# Altium schematic sheet Eco-Stationnement_Parking_LED.SchDoc(Eco-Stationnement_Parking_LED)
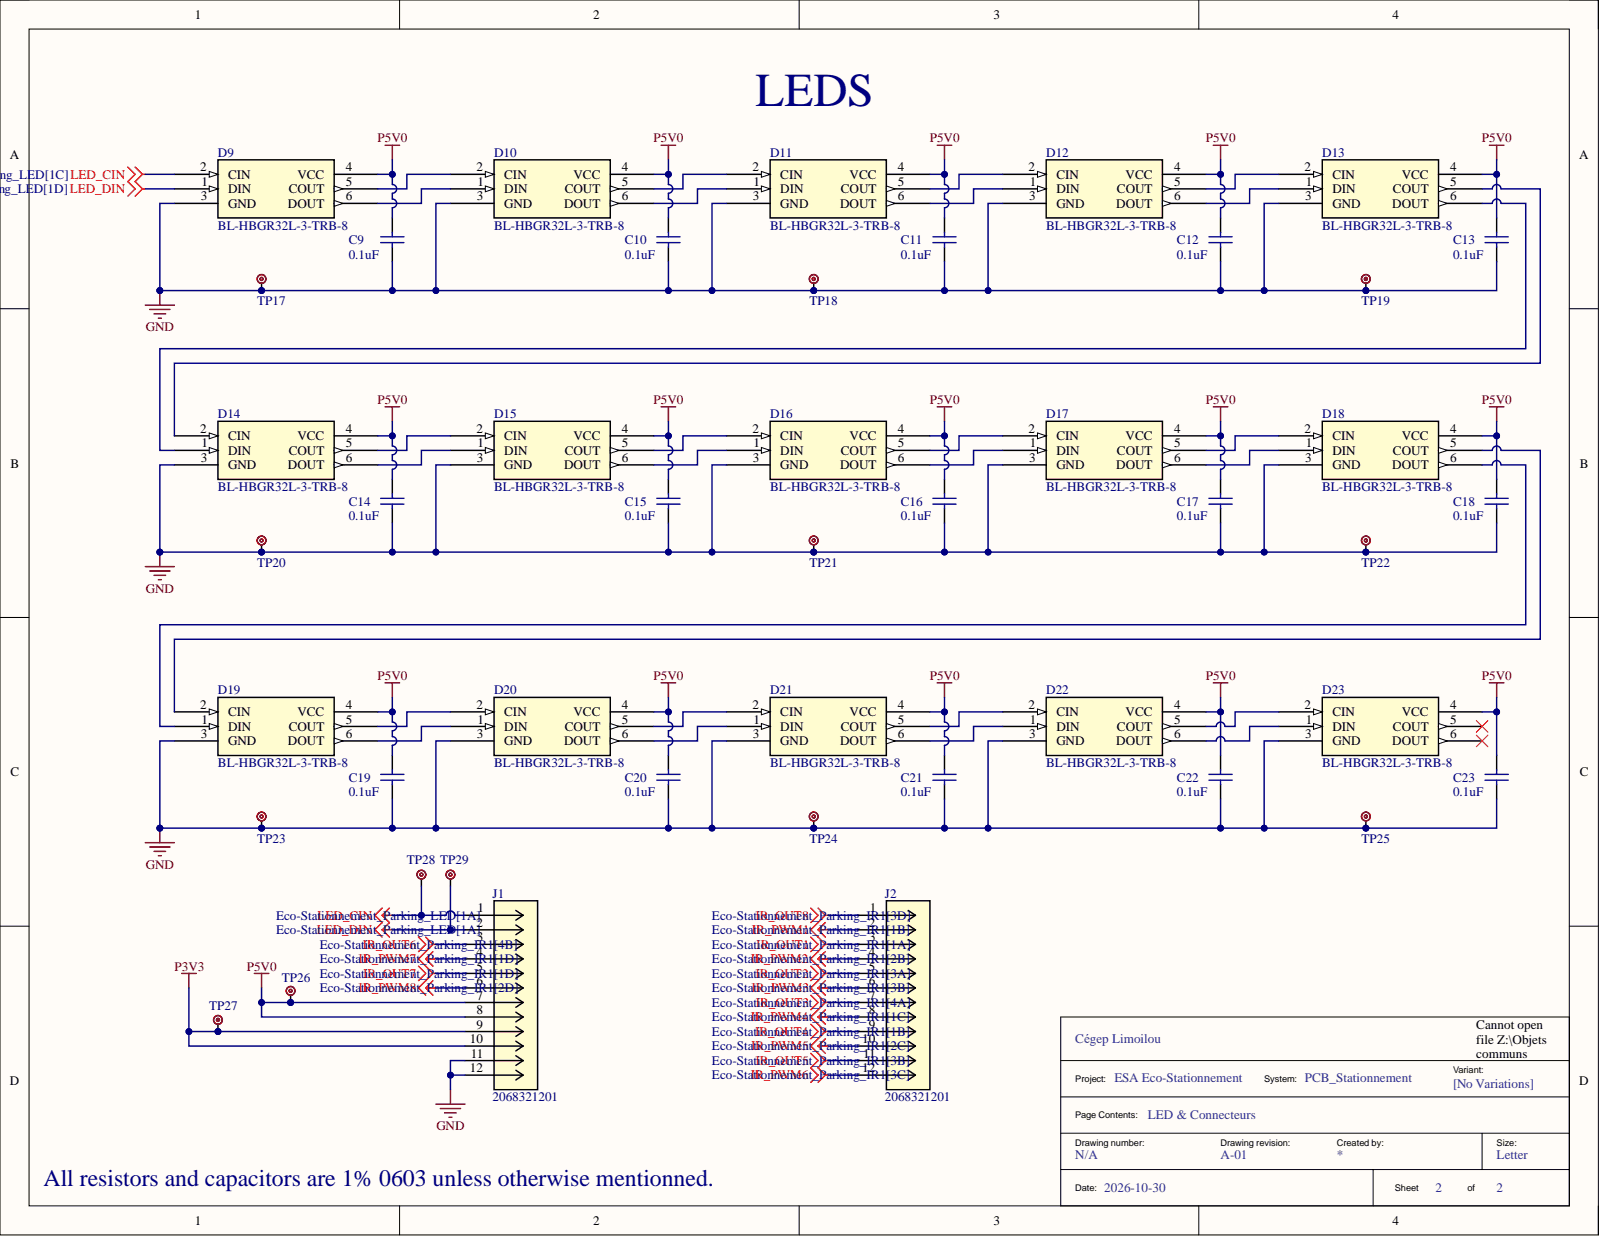
[diagram p0: Eco-Stationnement_Parking_LED.SchDoc]

## Parts
C9  value 0.1uF  [C0603]  (hidden comment: 06035C104KAT2A)
C10  value 0.1uF  [C0603]  (hidden comment: 06035C104KAT2A)
C11  value 0.1uF  [C0603]  (hidden comment: 06035C104KAT2A)
C12  value 0.1uF  [C0603]  (hidden comment: 06035C104KAT2A)
C13  value 0.1uF  [C0603]  (hidden comment: 06035C104KAT2A)
C14  value 0.1uF  [C0603]  (hidden comment: 06035C104KAT2A)
C15  value 0.1uF  [C0603]  (hidden comment: 06035C104KAT2A)
C16  value 0.1uF  [C0603]  (hidden comment: 06035C104KAT2A)
C17  value 0.1uF  [C0603]  (hidden comment: 06035C104KAT2A)
C18  value 0.1uF  [C0603]  (hidden comment: 06035C104KAT2A)
C19  value 0.1uF  [C0603]  (hidden comment: 06035C104KAT2A)
C20  value 0.1uF  [C0603]  (hidden comment: 06035C104KAT2A)
C21  value 0.1uF  [C0603]  (hidden comment: 06035C104KAT2A)
C22  value 0.1uF  [C0603]  (hidden comment: 06035C104KAT2A)
C23  value 0.1uF  [C0603]  (hidden comment: 06035C104KAT2A)
D9  BL-HBGR32L-3-TRB-8  [BL-HBGR32L-3-TRB-8_AMB]
D10  BL-HBGR32L-3-TRB-8  [BL-HBGR32L-3-TRB-8_AMB]
D11  BL-HBGR32L-3-TRB-8  [BL-HBGR32L-3-TRB-8_AMB]
D12  BL-HBGR32L-3-TRB-8  [BL-HBGR32L-3-TRB-8_AMB]
D13  BL-HBGR32L-3-TRB-8  [BL-HBGR32L-3-TRB-8_AMB]
D14  BL-HBGR32L-3-TRB-8  [BL-HBGR32L-3-TRB-8_AMB]
D15  BL-HBGR32L-3-TRB-8  [BL-HBGR32L-3-TRB-8_AMB]
D16  BL-HBGR32L-3-TRB-8  [BL-HBGR32L-3-TRB-8_AMB]
D17  BL-HBGR32L-3-TRB-8  [BL-HBGR32L-3-TRB-8_AMB]
D18  BL-HBGR32L-3-TRB-8  [BL-HBGR32L-3-TRB-8_AMB]
D19  BL-HBGR32L-3-TRB-8  [BL-HBGR32L-3-TRB-8_AMB]
D20  BL-HBGR32L-3-TRB-8  [BL-HBGR32L-3-TRB-8_AMB]
D21  BL-HBGR32L-3-TRB-8  [BL-HBGR32L-3-TRB-8_AMB]
D22  BL-HBGR32L-3-TRB-8  [BL-HBGR32L-3-TRB-8_AMB]
D23  BL-HBGR32L-3-TRB-8  [BL-HBGR32L-3-TRB-8_AMB]
J1  2068321201  [CONN_2068320000-SD_12_MOL]
J2  2068321201  [CONN_2068320000-SD_12_MOL]
TP17  5000  [TP_5000_MIN]  (value not drawn)
TP18  5000  [TP_5000_MIN]  (value not drawn)
TP19  5000  [TP_5000_MIN]  (value not drawn)
TP20  5000  [TP_5000_MIN]  (value not drawn)
TP21  5000  [TP_5000_MIN]  (value not drawn)
TP22  5000  [TP_5000_MIN]  (value not drawn)
TP23  5000  [TP_5000_MIN]  (value not drawn)
TP24  5000  [TP_5000_MIN]  (value not drawn)
TP25  5000  [TP_5000_MIN]  (value not drawn)
TP26  5000  [TP_5000_MIN]  (value not drawn)
TP27  5000  [TP_5000_MIN]  (value not drawn)
TP28  5000  [TP_5000_MIN]  (value not drawn)
TP29  5000  [TP_5000_MIN]  (value not drawn)

## Nets
GND: C9.1 C10.1 C11.1 C12.1 C13.1 C14.1 C15.1 C16.1 C17.1 C18.1 C19.1 C20.1 C21.1 C22.1 C23.1 D9.3(GND) D10.3(GND) D11.3(GND) D12.3(GND) D13.3(GND) D14.3(GND) D15.3(GND) D16.3(GND) D17.3(GND) D18.3(GND) D19.3(GND) D20.3(GND) D21.3(GND) D22.3(GND) D23.3(GND) J1.11 J1.12 TP17.1 TP18.1 TP19.1 TP20.1 TP21.1 TP22.1 TP23.1 TP24.1 TP25.1
IR_OUT1: J2.3
IR_OUT2: J2.5
IR_OUT3: J2.7
IR_OUT4: J2.9
IR_OUT5: J2.11
IR_OUT6: J1.3
IR_OUT7: J1.5
IR_OUT8: J2.1
IR_PWM1: J2.2
IR_PWM2: J2.4
IR_PWM3: J2.6
IR_PWM4: J2.8
IR_PWM5: J2.10
IR_PWM6: J2.12
IR_PWM7: J1.4
IR_PWM8: J1.6
LED_CIN: D9.2(CIN) J1.1 TP28.1
LED_DIN: D9.1(DIN) J1.2 TP29.1
P3V3: J1.9 J1.10 TP27.1
P5V0: C9.2 C10.2 C11.2 C12.2 C13.2 C14.2 C15.2 C16.2 C17.2 C18.2 C19.2 C20.2 C21.2 C22.2 C23.2 D9.4(VCC) D10.4(VCC) D11.4(VCC) D12.4(VCC) D13.4(VCC) D14.4(VCC) D15.4(VCC) D16.4(VCC) D17.4(VCC) D18.4(VCC) D19.4(VCC) D20.4(VCC) D21.4(VCC) D22.4(VCC) D23.4(VCC) J1.7 J1.8 TP26.1
NetD9_5: D9.5(COUT) D10.2(CIN)
NetD9_6: D9.6(DOUT) D10.1(DIN)
NetD10_5: D10.5(COUT) D11.2(CIN)
NetD10_6: D10.6(DOUT) D11.1(DIN)
NetD11_5: D11.5(COUT) D12.2(CIN)
NetD11_6: D11.6(DOUT) D12.1(DIN)
NetD12_5: D12.5(COUT) D13.2(CIN)
NetD12_6: D12.6(DOUT) D13.1(DIN)
NetD13_5: D13.5(COUT) D14.2(CIN)
NetD13_6: D13.6(DOUT) D14.1(DIN)
NetD14_5: D14.5(COUT) D15.2(CIN)
NetD14_6: D14.6(DOUT) D15.1(DIN)
NetD15_5: D15.5(COUT) D16.2(CIN)
NetD15_6: D15.6(DOUT) D16.1(DIN)
NetD16_5: D16.5(COUT) D17.2(CIN)
NetD16_6: D16.6(DOUT) D17.1(DIN)
NetD17_5: D17.5(COUT) D18.2(CIN)
NetD17_6: D17.6(DOUT) D18.1(DIN)
NetD18_5: D18.5(COUT) D19.2(CIN)
NetD18_6: D18.6(DOUT) D19.1(DIN)
NetD19_5: D19.5(COUT) D20.2(CIN)
NetD19_6: D19.6(DOUT) D20.1(DIN)
NetD20_5: D20.5(COUT) D21.2(CIN)
NetD20_6: D20.6(DOUT) D21.1(DIN)
NetD21_5: D21.5(COUT) D22.2(CIN)
NetD21_6: D21.6(DOUT) D22.1(DIN)
NetD22_5: D22.5(COUT) D23.2(CIN)
NetD22_6: D22.6(DOUT) D23.1(DIN)

Not connected: D23 5(COUT) 6(DOUT)

## Library data of the parts
C9-C23 = CAP0021: CAP CER 0.1UF 50V X7R 0603 10%; Manufacturer: KYOCERA AVX; ID_CEP: MCAP0021; Verif_1: RCA le 2022-02-21; Component Kind: Standard; Dielectric: X7R; Fitted: Fitted; Package: 0603; PN_Manuf: 06035C104KAT2A; Library Name: Eco-Stationnement_Parking.SchLib
D9-D23 = BL-HBGR32L-3-TRB-8: ADDRESS LED DISCRETE SERIAL RGB; Manufacturer: American Bright; Component Kind: Standard; Fitted: Fitted; Manufacturer_Name: American Bright; Manufacturer_Part_Number: BL-HBGR32L-3-TRB-8; PN_Manuf: BL-HBGR32L-3-TRB-8; RefDes: RefDes; Type: Type; Copyright: Copyright (C) 2025 Ultra Librarian. All rights reserved.; Library Name: Eco-Stationnement_Parking.SchLib
J1 J2 = 2068321201: Micro-Fit+ Vertical Header, Through Hole, 3.00mm Pitch, Dual Row, 12 Circuits, Tin (Sn) Plating, Glow-Wire Capable, Black, Tray; Manufacturer: Molex Connector Corporation; Component Kind: Standard; Datasheet: https://www.molex.com/en-us/products/part-detail-pdf/2068321201?display=pdf; Fitted: Fitted; Manufacturer_Name: Molex Connector Corporation; Manufacturer_Part_Number: 2068321201; PN_Manuf: 2068321201; RefDes: RefDes; Type: Type; Copyright: Copyright (C) 2026 Ultra Librarian. All rights reserved.; Library Name: Eco-Stationnement_Parking.SchLib
TP17-TP29 = DIV0034: PC TEST POINT MINIATURE RED 1mm; Manufacturer: Keystone Electronics; Fitted: Not Fitted; ID_CEP: MDIV0034; Verif_1: RCA le 2024-03-27; Component Kind: Standard; PN_Manuf: 5000; Library Name: Eco-Stationnement_Parking.SchLib
